# Altium schematic sheet RF.SchDoc(U_RF)
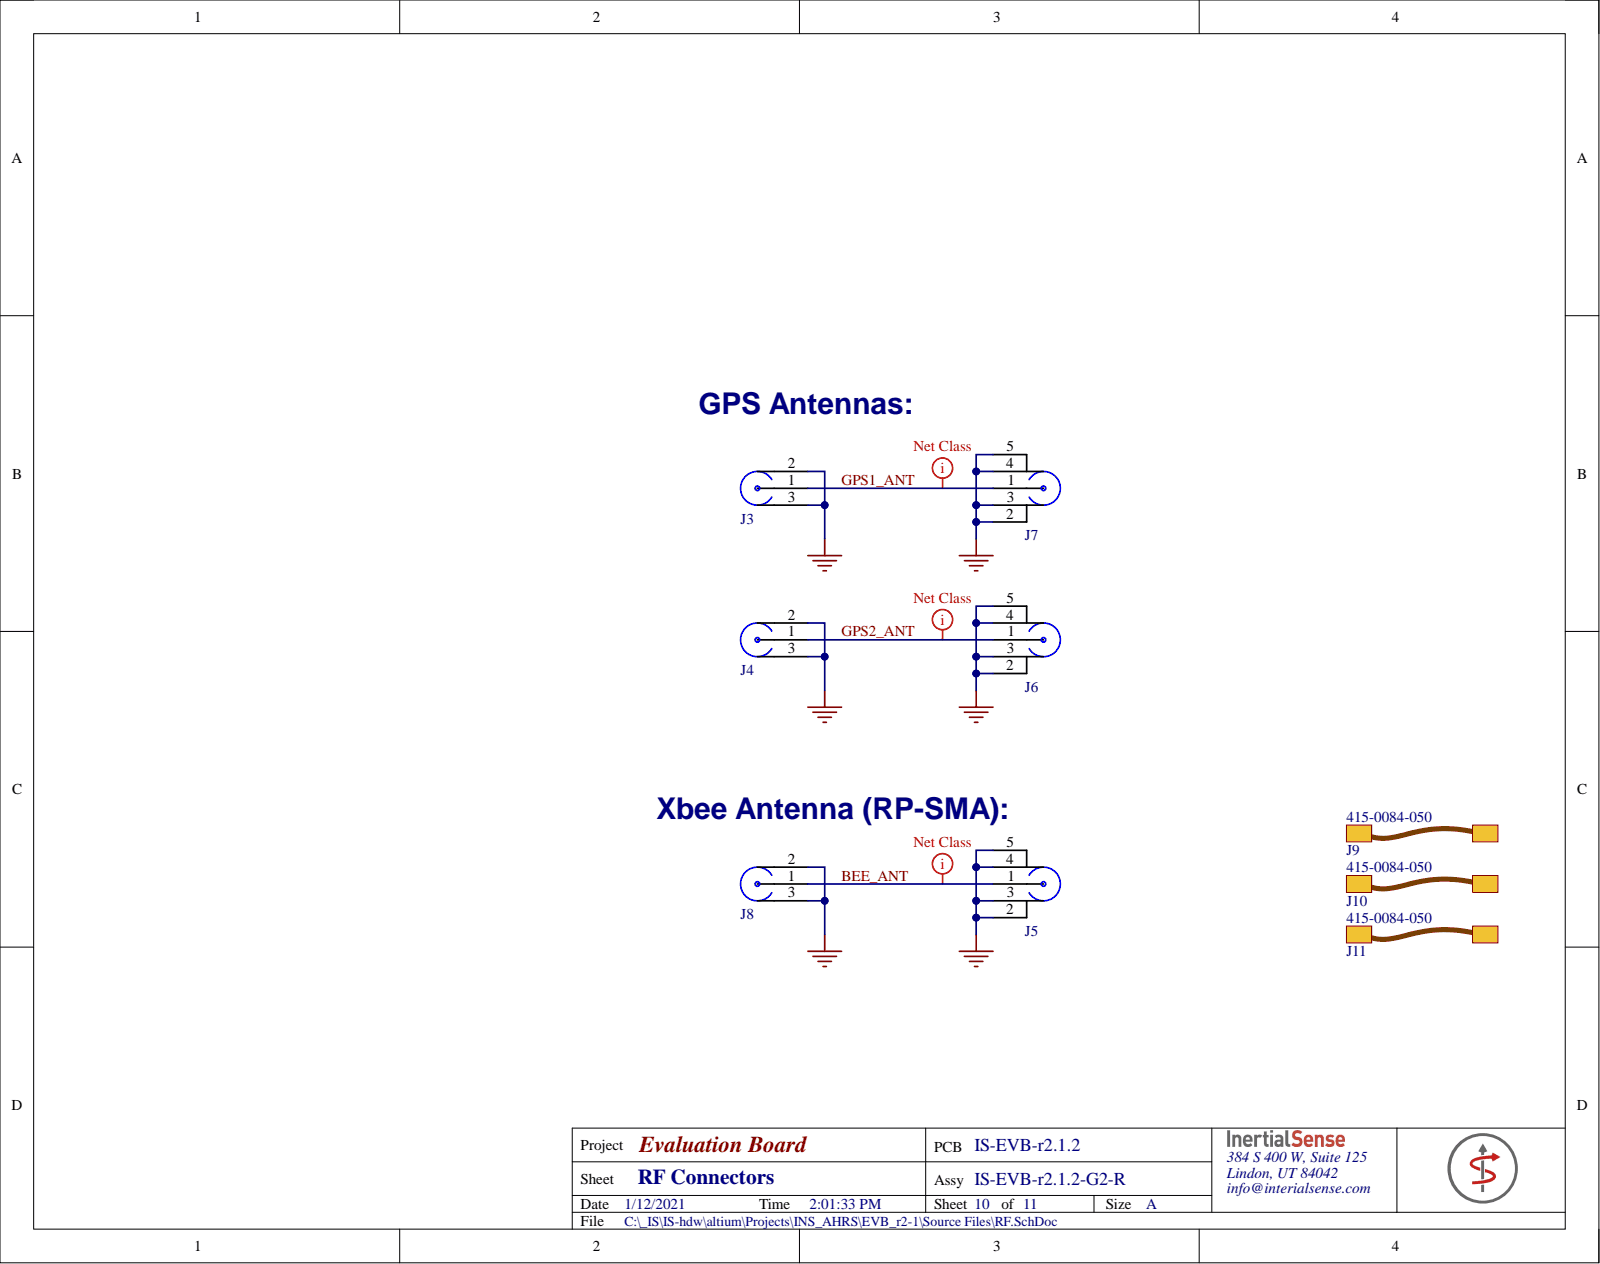
[diagram p0: RF.SchDoc]

## Parts
J3  RF - GND x 2  [RF UMC SMD]  (value not drawn)
J4  RF - GND x 2  [RF UMC SMD]  (value not drawn)
J5  RF - GND x 4  [RF RPSMA End Launch]  (value not drawn)
J6  RF - GND x 4  [RF SMA End Launch 2]  (value not drawn)
J7  RF - GND x 4  [RF SMA End Launch 2]  (value not drawn)
J8  RF - GND x 2  [RF UMC SMD]  (value not drawn)
J9  Manufacturer Part Number: 415-0084-050  (hidden comment: Cable Assembly)  (mechanical)
J10  Manufacturer Part Number: 415-0084-050  (hidden comment: Cable Assembly)  (mechanical)
J11  Manufacturer Part Number: 415-0084-050  (hidden comment: Cable Assembly)  (mechanical)

## Nets
GND: J3.2 J3.3 J4.2 J4.3 J5.2 J5.3 J5.4 J5.5 J6.2 J6.3 J6.4 J6.5 J7.2 J7.3 J7.4 J7.5 J8.2 J8.3
BEE_ANT: J5.1 J8.1
GPS1_ANT: J3.1 J7.1
GPS2_ANT: J4.1 J6.1

## Library data of the parts
J3 J4 J8 = RF - GND x 2: CONN UMC RCPT STR 50 OHM SMD; Manufacturer: Emerson Network Power Connectivity Johnson; Manufacturer Part Number: 128-0711-201; Body Finish: Gold; Connector Style: Ultra Miniature Coaxial; Connector Type: Receptacle, Male Pins; Contact Termination: Solder; Frequency - Max: 6GHz; Impedance: 50 Ohm; Voltage Rating: 200V; Body Material: -; Cable Group: -; Category: Connectors, Interconnects; Center Contact Material: -; Center Contact Plating: Gold; Component Kind: Standard; ComponentLink1Description: http://media.digikey.com/pdf/Data%20Sheets/Emerson%20PDFs/UMC%20Product%20Catalog.pdf; ComponentLink1URL: http://media.digikey.com/pdf/Data%20Sheets/Emerson%20PDFs/UMC%20Product%20Catalog.pdf; Dielectric Material: -; Fastening Type: Snap-On; Features: -; Housing Color: Gold; Ingress Protection: -; Library Name: Connectors.SchLib; Mounting Type: Surface Mount; Operating Temperature: -40°C ~ 90°C; Packaging: Cut Tape (CT); Pricing 1: 1=0.93, 10=0.832, 50=0.784, 100=0.672, 250=0.576, 500=0.544, 750=0.48, 1000=0.448 (USD); RoHS: RoHS Compliant; Shield Termination: Solder; Stock 1: 1514; Supplier 1: Digi-Key; Supplier Part Number 1: J983CT-ND
J5 = RF - GND x 4: CONN RPSMA JCK STR 50OHM EDGEMNT; Manufacturer: Amphenol Connex; Manufacturer Part Number: 132255RP; Board Thickness: 0.062inch; Body Material: Brass; Body Plating: Gold; Connector Type: Coaxial,Male Pin,SMA; Dielectric Material: PTFE; Frequency: 18GHz; Impedance: 50R; Mating Cycles: 500; Voltage Rating: 375V; Case/Package: SMA; Component Kind: Standard; Contact Material: Copper; Contact Plating: Gold; Depth: 7.92mm; Fastening Type: Threaded; Housing Colour: Gold; Insulation Material: Polytetrafluoroethylene; Length: 9.52mm; Library Name: Connectors.SchLib; Max Frequency: 18GHz; Max Operating Temperature: 165°C; Min Operating Temperature: -65°C; Mount: Edge,PCB; Orientation: Straight; Package Quantity: 1; Packaging: Bulk; Pricing 1: 1=7.75, 10=7.429, 25=6.7832, 50=6.46, 100=6.137, 250=5.491, 500=5.168, 1000=4.522 (USD); Radiation Hardening: No; REACH SVHC: Unknown; Stock 1: 513; Supplier 1: Digi-Key; Supplier Part Number 1: ACX1425-ND; Termination: Solder; Weight: 0.126986oz
J6 J7 = RF - GND x 4: CONN SMA RCPT STR 50OHM EDGE MNT; Manufacturer: Molex; Manufacturer Part Number: 0732511150; Body Material: Brass; Body Plating: Gold; Connector Type: SMA,Socket; Dielectric Material: PTFE; Impedance: 50R; Max Frequency: 18GHz; Voltage Rating: 500V; Case/Package: SMA; Component Kind: Standard; Contact Material: Copper; Contact Plating: Gold; Depth: 9.53mm; Fastening Type: Threaded; Housing Colour: Gold; Insulation Material: Polytetrafluoroethylene; Lead Free: Lead Free; Length: 9.53mm; Library Name: Connectors.SchLib; Max Operating Temperature: 165°C; Min Operating Temperature: -65°C; Mount: Edge; Package Quantity: 1600; Packaging: Tray; Pricing 1: 1=3.33, 10=3.193, 25=2.9272, 50=2.7942, 100=2.6612, 250=2.32856, 500=2.26202, 1600=1.86284, 3200=1.79631 (USD); Radiation Hardening: No; Stock 1: 22842; Supplier 1: Digi-Key; Supplier Part Number 1: WM5534-ND; Termination: Solder
J9-J11 = Cable Assembly: CBL ASSY UMCC PLUG-PLUG 1.969"; Manufacturer: Bel Cinch; Cable Length: 0.05m; Connector Type A: Coaxial,Plug,Socket; Gender: Female to Female; Gender Type A: Male; Impedance: 50R; Max Frequency: 6GHz; Polarity: Normal; Wire/Cable Type: Coaxial; Color: White; ComponentLink1Description: http://www.mouser.com/ds/2/643/dr-415-0084-050-738560.pdf; ComponentLink1URL: http://www.mouser.com/ds/2/643/dr-415-0084-050-738560.pdf; Connector Type B: Coaxial,Plug,Socket; Contact Plating: Gold; Features: Shielded; Gender Type B: Male; Length: 1.97inch; Library Name: Connectors.SchLib; Max Operating Temperature: 90°C; Min Operating Temperature: -40°C; Mount: Cable; Package Quantity: 5; Packaging: Bulk; Radiation Hardening: No; Stock 1: 0; Supplier 1: Digi-Key; Supplier Part Number 1: J918-ND
